# Altium schematic sheet 1-FOB.SchDoc
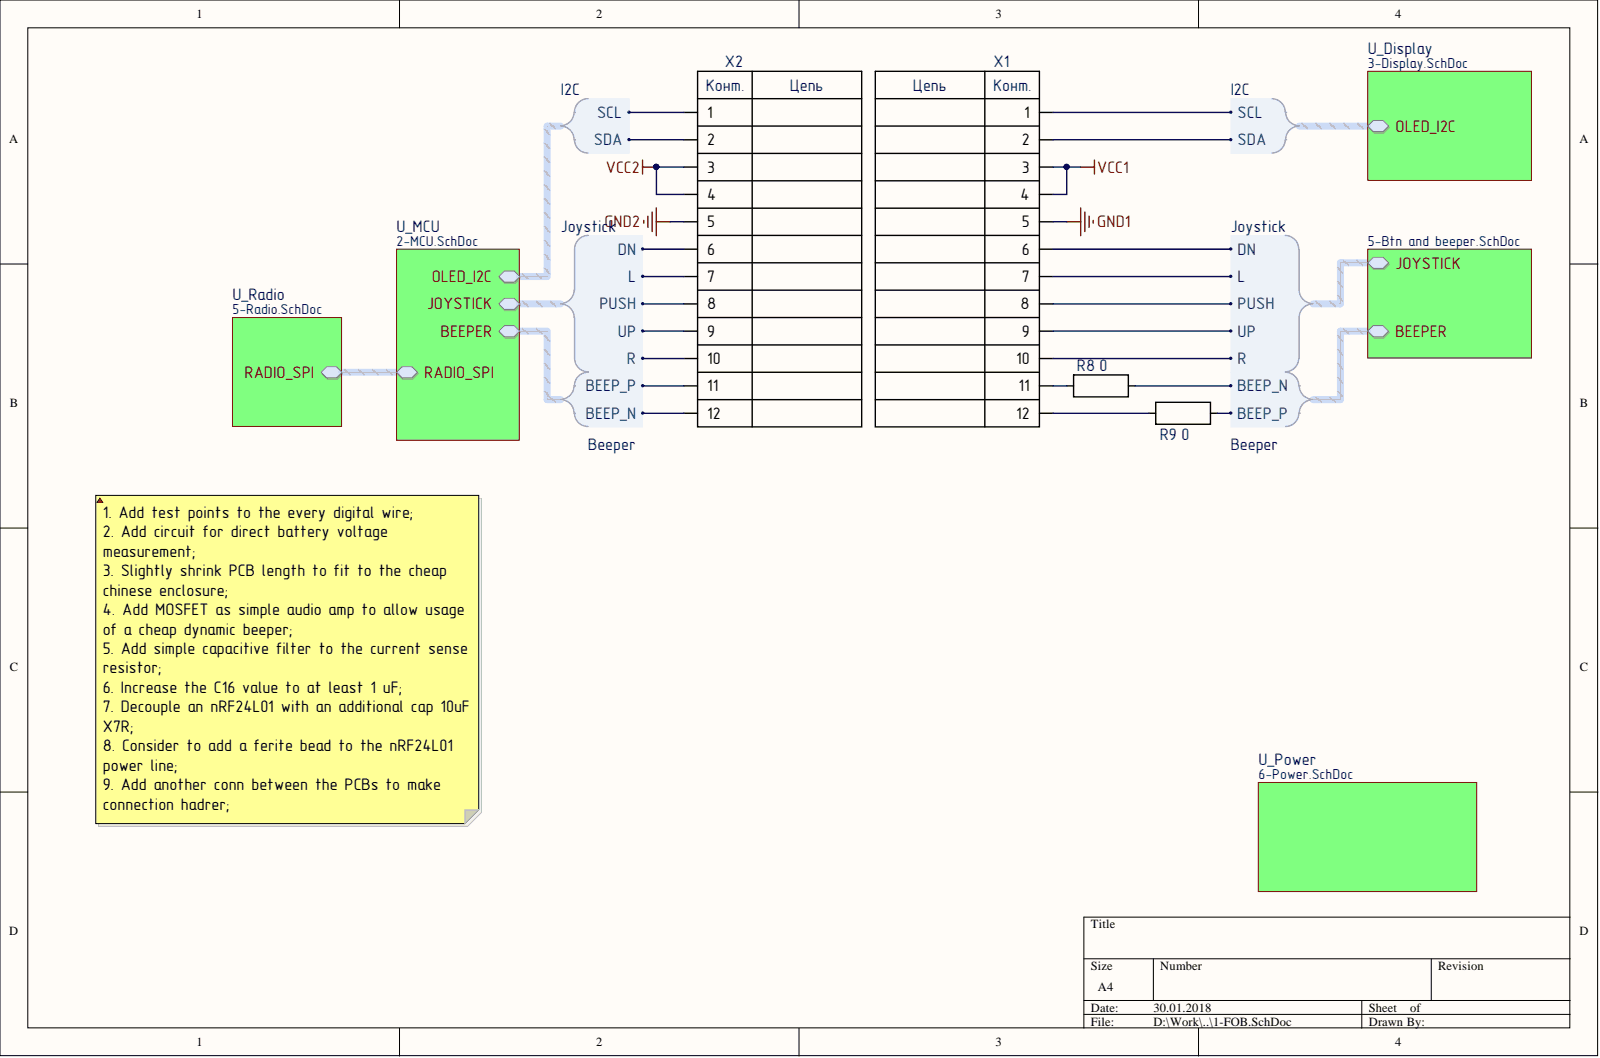
[diagram p0: 1-FOB.SchDoc]

## Parts
R8  value 0  [CHIP_0402_R]  (hidden comment: Резистор)
R9  value 0  [CHIP_0402_R]  (hidden comment: Резистор)
X1  PLD-12  [PLD1.27-12 SMD]  (value not drawn)
X2  PBD-12  [PBD1.27-12 SMD]  (value not drawn)

## Nets
GND1: X1.5
GND2: X2.5
VCC1: X1.3 X1.4
VCC2: X2.3 X2.4
NetR8_1: R8.1 X1.11
NetR8_2: R8.2
NetR9_1: R9.1 X1.12
NetR9_2: R9.2
NetX1_1: X1.1
NetX1_2: X1.2
NetX1_6: X1.6
NetX1_7: X1.7
NetX1_8: X1.8
NetX1_9: X1.9
NetX1_10: X1.10
NetX2_1: X2.1
NetX2_2: X2.2
NetX2_6: X2.6
NetX2_7: X2.7
NetX2_8: X2.8
NetX2_9: X2.9
NetX2_10: X2.10
NetX2_11: X2.11
NetX2_12: X2.12
RADIO_SPI.CE:
RADIO_SPI.IRQ:
RADIO_SPI.MISO:
RADIO_SPI.MOSI:
RADIO_SPI.nCS:
RADIO_SPI.SCK:

## Library data of the parts
R8 R9 = Резистор: PartNumber: RC0402JR-070RL; Mfg: YAGEO; Component Kind: Standard; Description: Резистор; Library Name: RCL.IntLib
X1 = PLD-12: PartNumber: PLLD1.27-80 (2X40) SMD; Component Kind: Standard; Library Name: conn.IntLib
X2 = PBD-12: PartNumber: PBD1.27-12 (2X06) SMD; Component Kind: Standard; Library Name: conn.IntLib
